# Altium schematic sheet Switching_Circuit.SchDoc
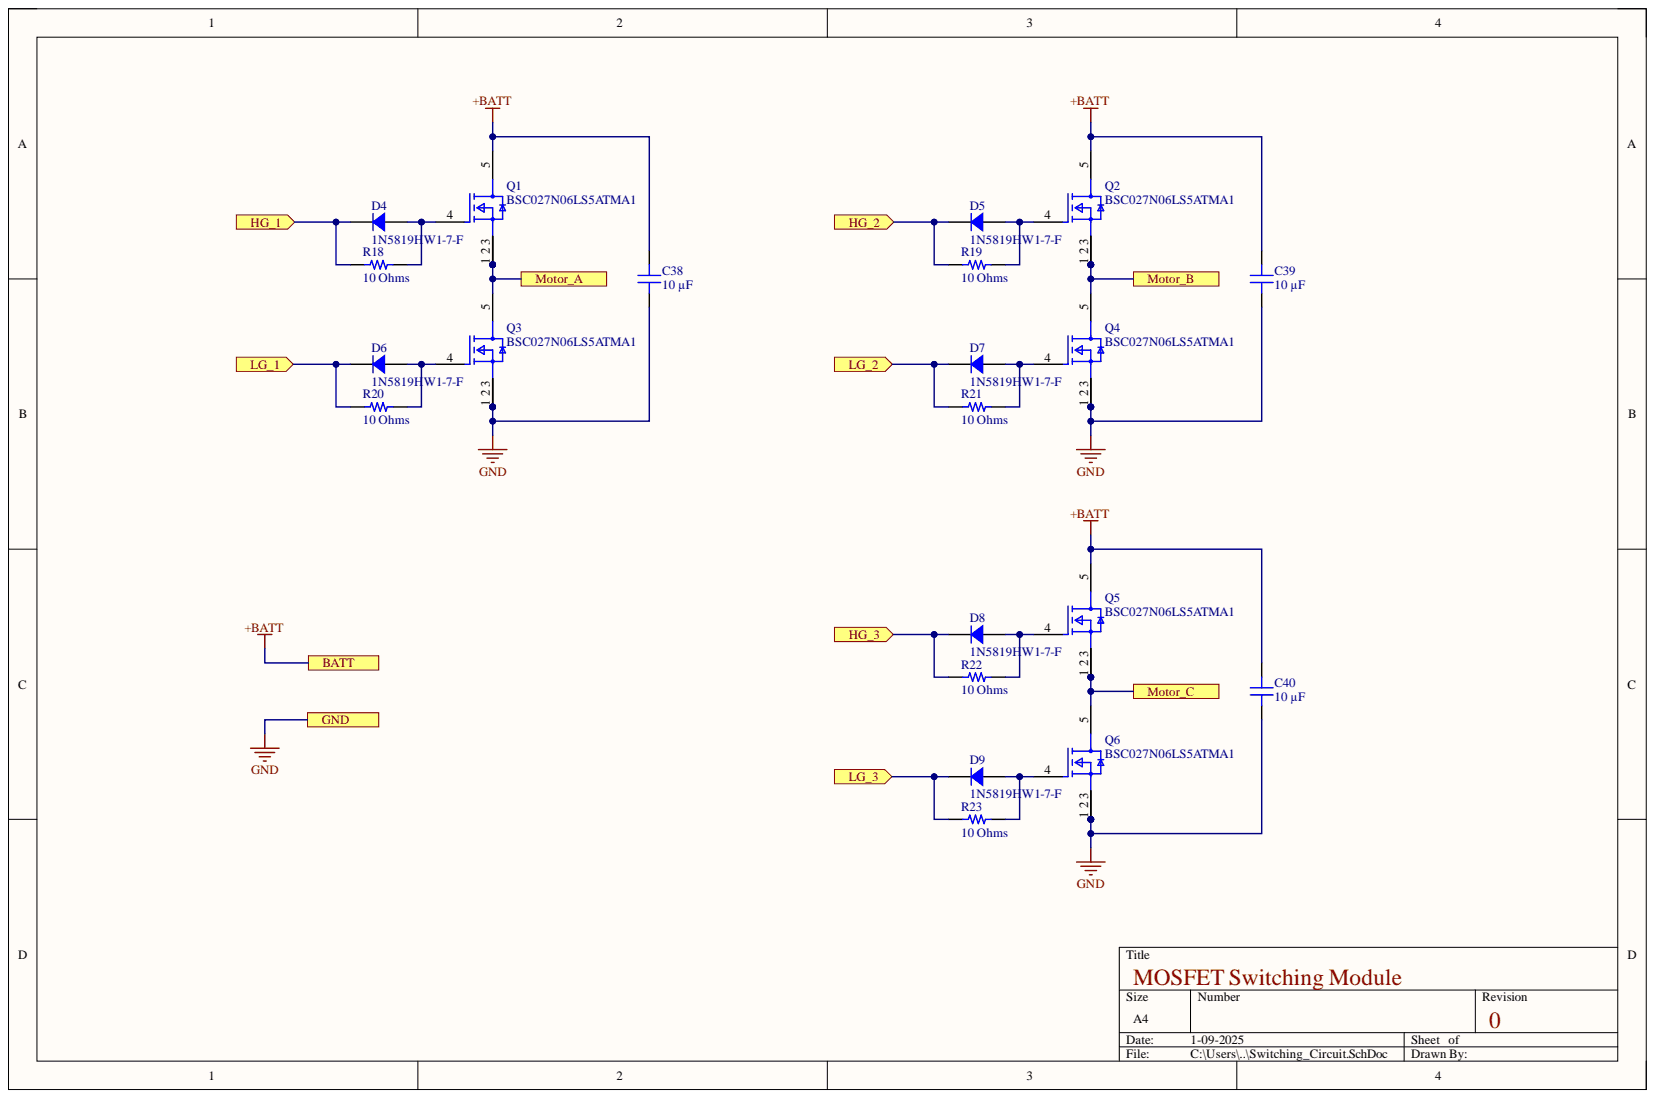
[diagram p0: Switching_Circuit.SchDoc]

## Parts
C38  10 µF  [CAP 1206_3216 - 0.8MM]
C39  10 µF  [CAP 1206_3216 - 0.8MM]
C40  10 µF  [CAP 1206_3216 - 0.8MM]
D4  1N5819HW1-7-F  [SOD123F]
D5  1N5819HW1-7-F  [SOD123F]
D6  1N5819HW1-7-F  [SOD123F]
D7  1N5819HW1-7-F  [SOD123F]
D8  1N5819HW1-7-F  [SOD123F]
D9  1N5819HW1-7-F  [SOD123F]
Q1  BSC027N06LS5ATMA1  [FP-PG-TDSON-8-MFG]
Q2  BSC027N06LS5ATMA1  [FP-PG-TDSON-8-MFG]
Q3  BSC027N06LS5ATMA1  [FP-PG-TDSON-8-MFG]
Q4  BSC027N06LS5ATMA1  [FP-PG-TDSON-8-MFG]
Q5  BSC027N06LS5ATMA1  [FP-PG-TDSON-8-MFG]
Q6  BSC027N06LS5ATMA1  [FP-PG-TDSON-8-MFG]
R18  10 Ohms  [YAGEO RES 0603_1608]
R19  10 Ohms  [YAGEO RES 0603_1608]
R20  10 Ohms  [YAGEO RES 0603_1608]
R21  10 Ohms  [YAGEO RES 0603_1608]
R22  10 Ohms  [YAGEO RES 0603_1608]
R23  10 Ohms  [YAGEO RES 0603_1608]

## Ports
BATT, GND, HG_1, HG_2, HG_3, LG_1, LG_2, LG_3, Motor_A, Motor_B, Motor_C

## Nets
+BATT: C38.2 C39.2 C40.2 Q1.5 Q2.5 Q5.5 ; port BATT
GND: C38.1 C39.1 C40.1 Q3.1 Q3.2 Q3.3 Q4.1 Q4.2 Q4.3 Q6.1 Q6.2 Q6.3 ; port GND
HG_1: D4.2 R18.1 ; port HG_1
HG_2: D5.2 R19.1 ; port HG_2
HG_3: D8.2 R22.1 ; port HG_3
LG_1: D6.2 R20.1 ; port LG_1
LG_2: D7.2 R21.1 ; port LG_2
LG_3: D9.2 R23.1 ; port LG_3
Motor_A: Q1.1 Q1.2 Q1.3 Q3.5 ; port Motor_A
Motor_B: Q2.1 Q2.2 Q2.3 Q4.5 ; port Motor_B
Motor_C: Q5.1 Q5.2 Q5.3 Q6.5 ; port Motor_C
NetD4_1: D4.1 Q1.4 R18.2
NetD5_1: D5.1 Q2.4 R19.2
NetD6_1: D6.1 Q3.4 R20.2
NetD7_1: D7.1 Q4.4 R21.2
NetD8_1: D8.1 Q5.4 R22.2
NetD9_1: D9.1 Q6.4 R23.2

## Library data of the parts
C38-C40 = 885012108022: CAP CER 10UF 50V X5R 1206; manufacturer: Würth Elektronik; Database Table Name: Capacitors - Ceramic - 1206; Tolerance: ±10%; Applications: General Purpose; Capacitance: 10 µF; Component Kind: Standard; componentlink1description: Datasheet; componentlink1url: https://www.we-online.com/catalog/datasheet/885012108022.pdf; componentlink2description: DigiKey Link; componentlink2url: https://www.digikey.com/en/products/detail/würth-elektronik/885012108022/17829827; Device Package: 1206 (3216 Metric); lastupdated: 04-06-2024 19:10:08; Library Name: Celestial Altium Library - altium_library.DbLib; Lifecycle Status: Active; Minimum Order: 1; Mounting Type: Surface Mount, MLCC; Operating Temperature: -55°C ~ 85°C; Package / Case: 1206 (3216 Metric); packaging: TapeAndReel; Part Number: 885012108022; Part Status: Active; partid: 49462; price: 1.51; series: WCAP-CSGP; Size / Dimension: 0.126" L x 0.063" W (3.20mm x 1.60mm); Supplier 1: DigiKey; Supplier Part Number 1: 732-885012108022CT-ND; Temperature Coefficient: X5R; Thickness (Max): 0.071" (1.80mm); Value: 10 µF; Voltage - Rated: 50V
D4-D9 = 1N5819HW1-7-F: DIODE SBR 40V 1A SOD123F; Manufacturer: Diodes Incorporated; Capacitance @ Vr, F: 30pF @ 10V, 1MHz; Current - Reverse Leakage @ Vr: 500 µA @ 40 V; Database Table Name: Diodes - Rectifiers - Single; Diode Type: Super Barrier; Reverse Recovery Time (trr): 15 ns; Speed: Fast Recovery =< 500ns, > 200mA (Io); Voltage - Forward (Vf) (Max) @ If: 510 mV @ 1 A; Component Kind: Standard; ComponentLink1Description: Datasheet; ComponentLink1URL: https://www.diodes.com/assets/Datasheets/1N5819HW1.pdf; ComponentLink2Description: DigiKey Link; ComponentLink2URL: https://www.digikey.com/en/products/detail/diodes-incorporated/1N5819HW1-7-F/5768523; Current - Average Rectified (Io): 1A; LastUpdated: 03-06-2024 19:54:57; Library Name: Celestial Altium Library - altium_library.DbLib; Lifecycle Status: Active; Minimum Order: 1; Mounting Type: Surface Mount; Operating Temperature - Junction: -55°C ~ 125°C; Package / Case: SOD-123F; Packaging: TapeAndReel; Part Number: 1N5819HW1-7-F; Part Status: Active; PartId: 2408; Price: 0.08; Series: SBR®; Supplier 1: DigiKey; Supplier Device Package: SOD-123F; Supplier Part Number 1: 1N5819HW1-7-FDICT-ND; Voltage - DC Reverse (Vr) (Max): 40 V
Q1-Q6 = CMP-00037-1: Transistor Mosfet N-ch 60V 100A 8-PIN Tdson T/r; Input Capacitance: 4.4nF; Max Power Dissipation: 83W; Rds On Max: 2.7mR; Component Kind: Standard; Continuous Drain Current (ID): 100A; Drain to Source Voltage (Vdss): 60V; Halogen Free: Halogen Free; Lead Free: Contains Lead; Library Name: CSD Robocon Workspace; Max Dual Supply Voltage: 60V; Max Operating Temperature: 150°C; Min Operating Temperature: -55°C; Mounting Technology: Surface Mount; Packaging: Cut Tape; Pins: 8; RoHS Compliant: Yes; Series: OptiMOS™
R18-R23 = RC0603JR-0710RL: RES 10 OHM 5% 1/10W 0603; Manufacturer: Yageo; Database Table Name: Resistors - Surface Mount - 0603; Power (Watts): 0.1W, 1/10W; Temperature Coefficient: ±200ppm/°C; Component Kind: Standard; ComponentLink1Description: Datasheet; ComponentLink1URL: https://www.yageo.com/upload/media/product/productsearch/datasheet/rchip/PYu-RC_Group_51_RoHS_L_10.pdf; ComponentLink2Description: DigiKey Link; ComponentLink2URL: https://www.digikey.com/en/products/detail/yageo/RC0603JR-0710RL/726699; Composition: Thick Film; Device Package: 0603 (1608 Metric); Features: Moisture Resistant; Height - Seated (Max): 0.022" (0.55mm); LastUpdated: 25-07-2024 09:19:17; Library Name: Celestial Altium Library - altium_library.DbLib; Lifecycle Status: Active; Minimum Order: 1; Number of Terminations: 2; Operating Temperature: -55°C ~ 155°C; Package / Case: 0603 (1608 Metric); Packaging: TapeAndReel; Part Number: RC0603JR-0710RL; Part Status: Active; PartId: 24641; Price: 0.01; Resistance: 10 Ohms; Series: RC_L; Size / Dimension: 0.063" L x 0.031" W (1.60mm x 0.80mm); Supplier 1: DigiKey; Supplier Device Package: 0603; Supplier Part Number 1: 311-10GRCT-ND; Tolerance: ±5%; Value: 10 Ohms
